# Altium schematic sheet Pwr_ctrl_cam.SchDoc
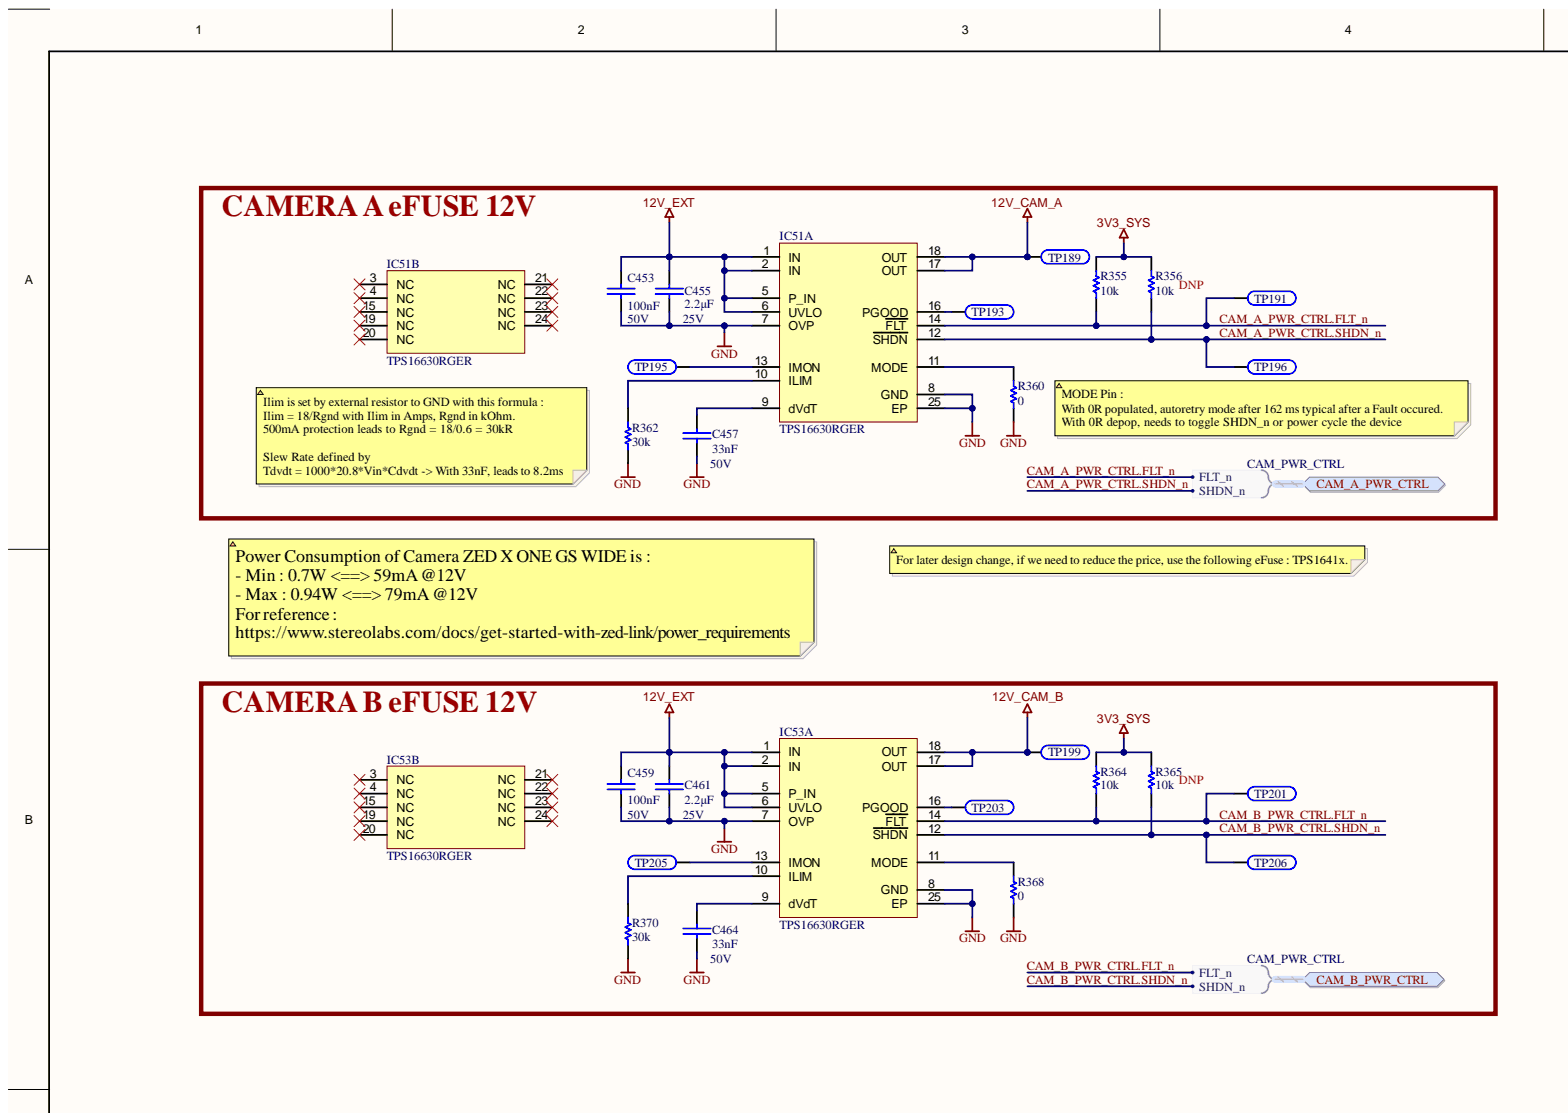
[diagram p0: Pwr_ctrl_cam.SchDoc, top left]
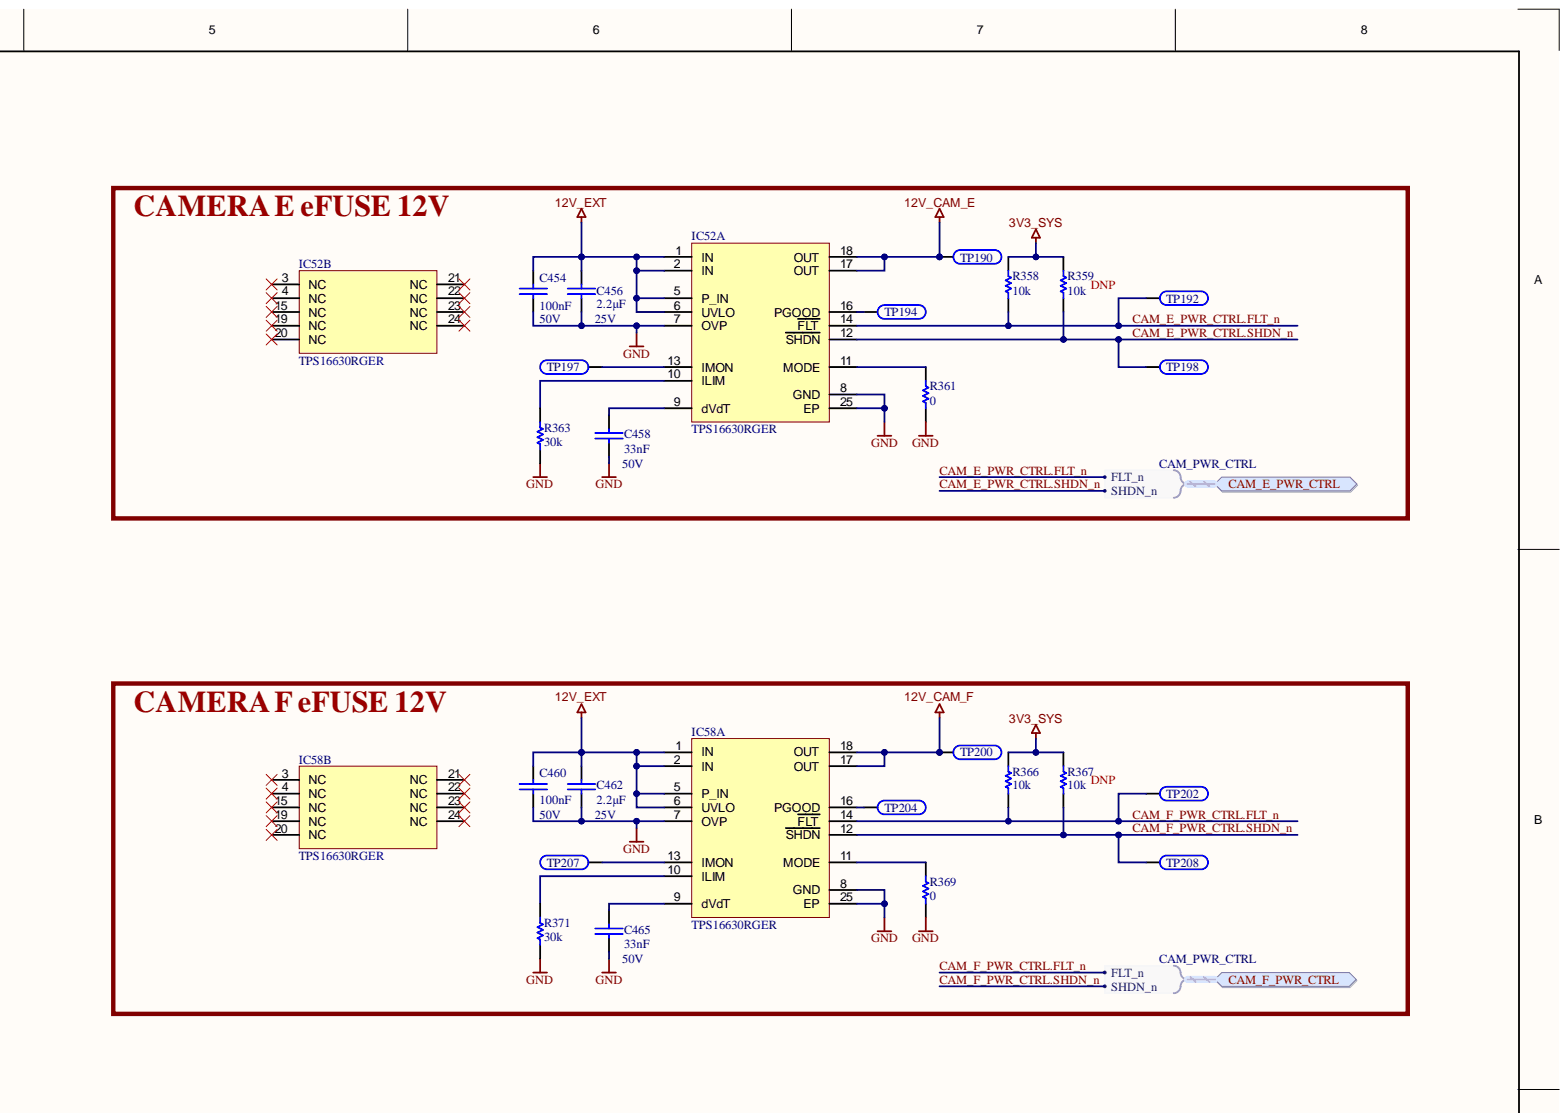
[diagram p1: Pwr_ctrl_cam.SchDoc, top right]
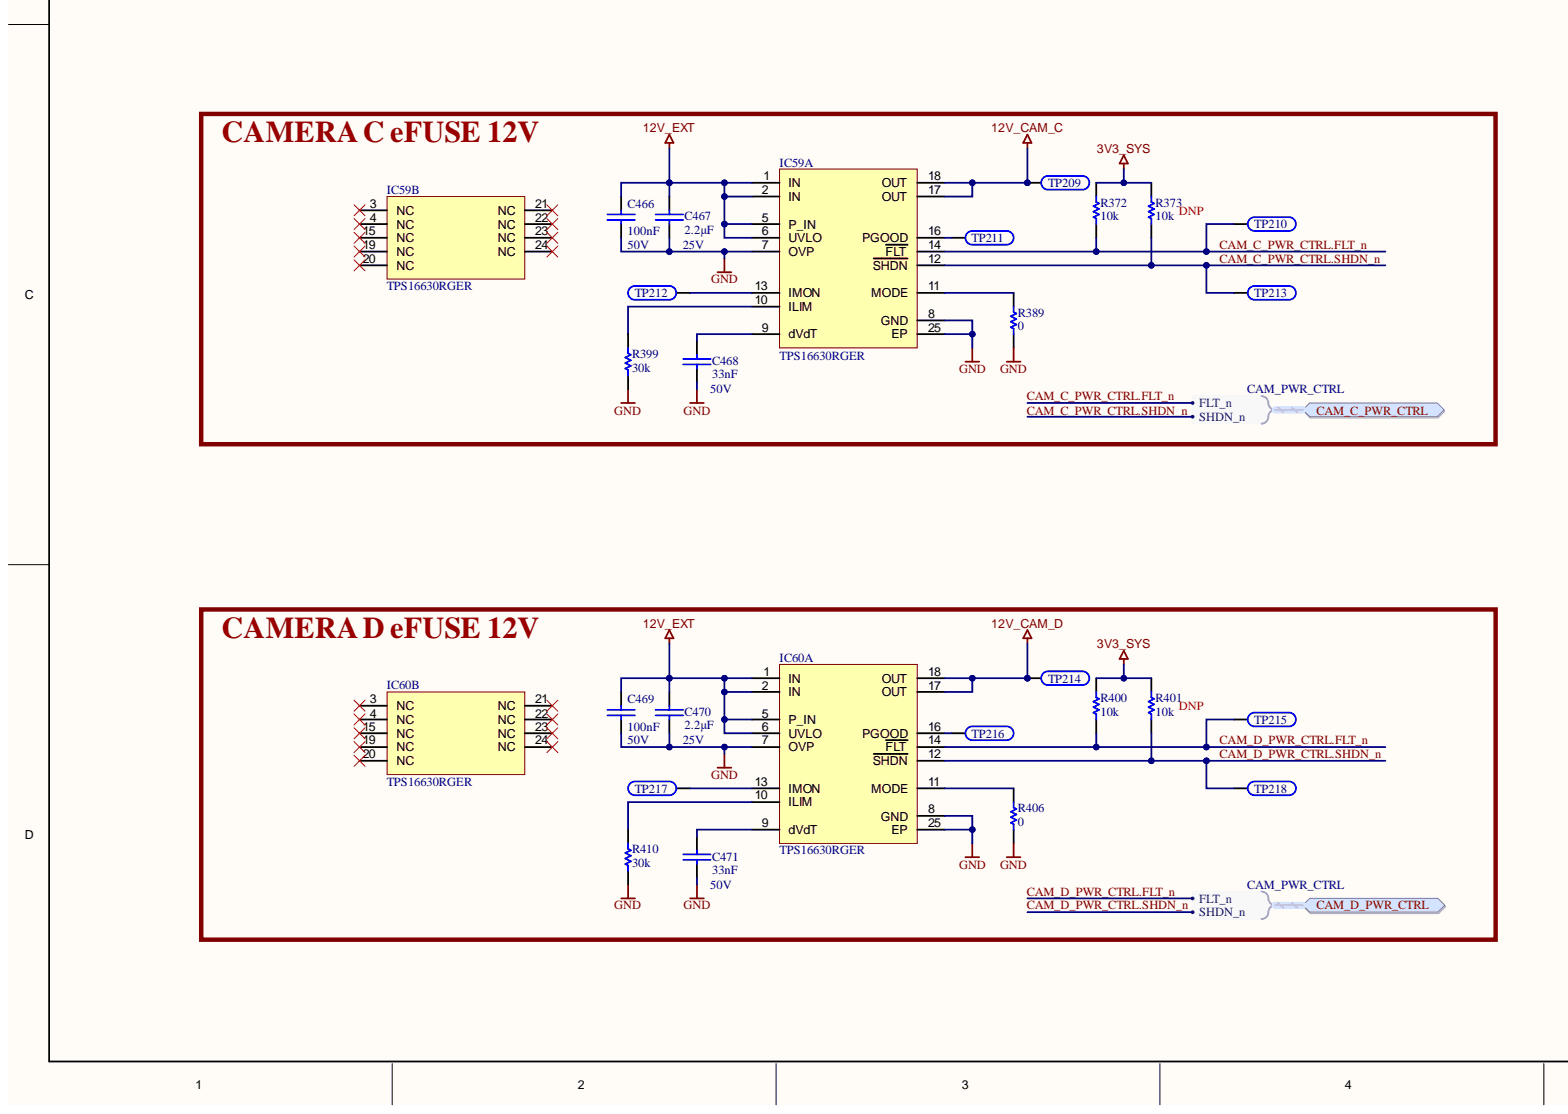
[diagram p2: Pwr_ctrl_cam.SchDoc, bottom left]
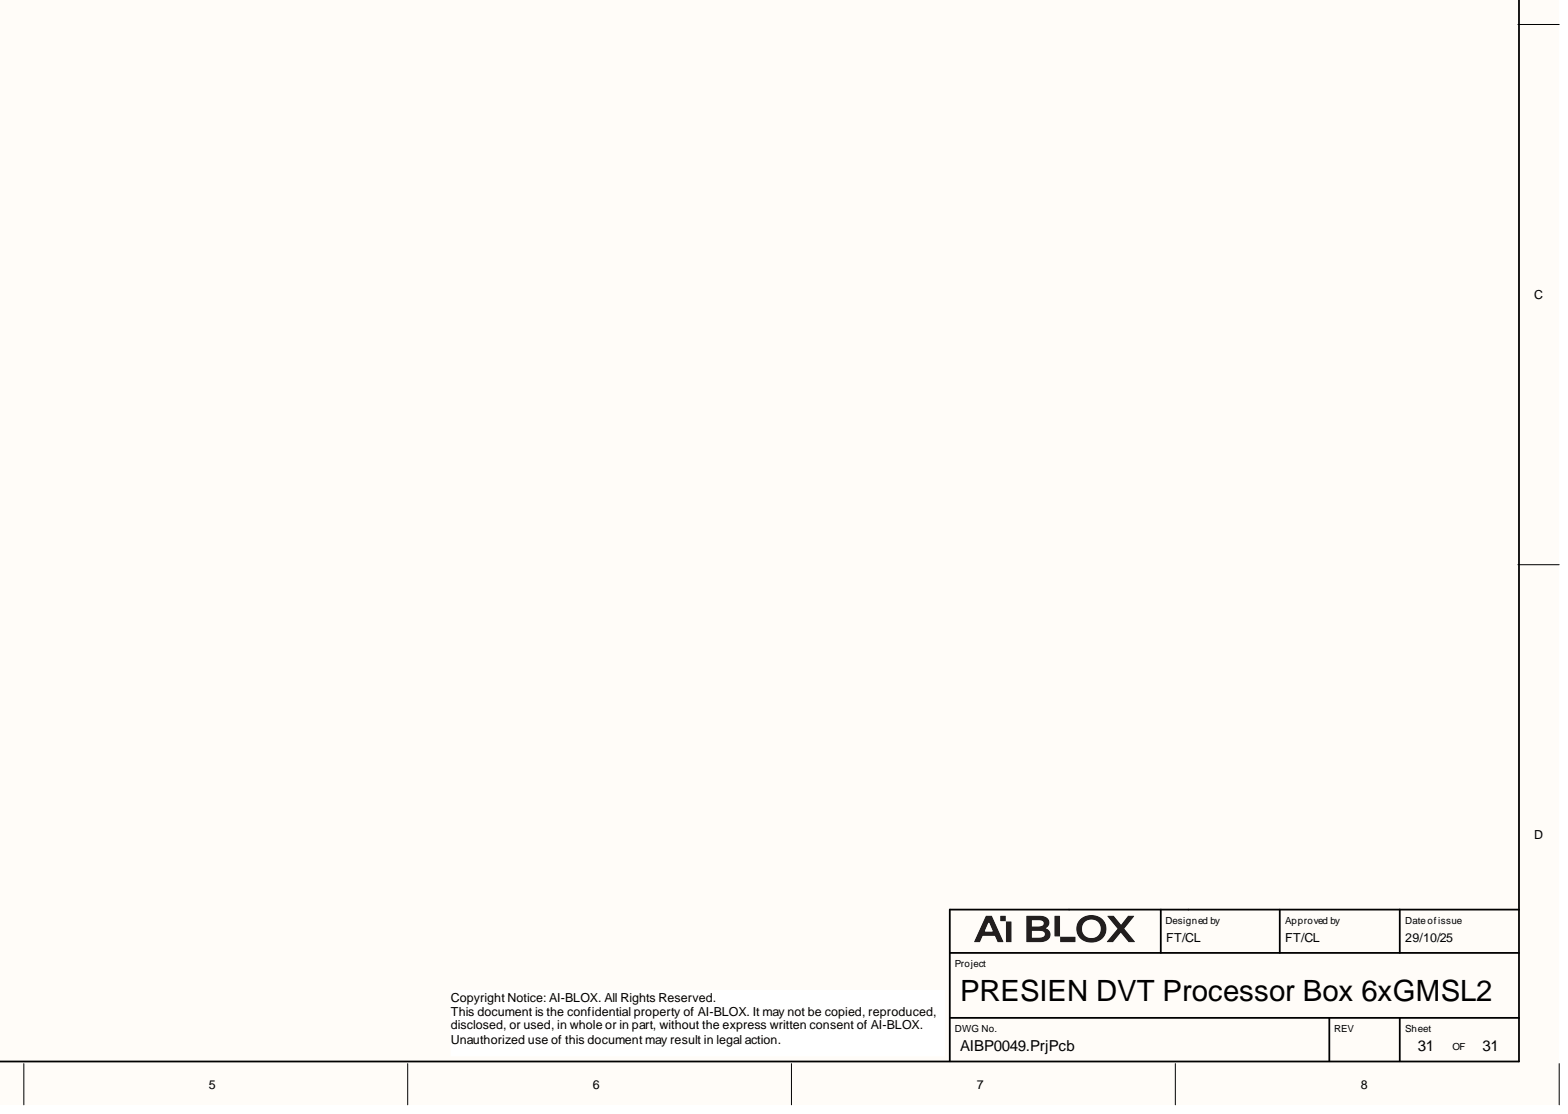
[diagram p3: Pwr_ctrl_cam.SchDoc, bottom right]

## Parts
C453  value 100nF  Voltage: 50V  [CAP0603-M]  (hidden comment: CC0603KPX7R9BB104)
C454  value 100nF  Voltage: 50V  [CAP0603-M]  (hidden comment: CC0603KPX7R9BB104)
C455  value 2.2µF  Voltage: 25V  [CAP0603-M]  (hidden comment: TMK107ABJ225KA-T)
C456  value 2.2µF  Voltage: 25V  [CAP0603-M]  (hidden comment: TMK107ABJ225KA-T)
C457  value 33nF  Voltage: 50V  [CAP0603-M]  (hidden comment: CC0603KRX7R9BB333)
C458  value 33nF  Voltage: 50V  [CAP0603-M]  (hidden comment: CC0603KRX7R9BB333)
C459  value 100nF  Voltage: 50V  [CAP0603-M]  (hidden comment: CC0603KPX7R9BB104)
C460  value 100nF  Voltage: 50V  [CAP0603-M]  (hidden comment: CC0603KPX7R9BB104)
C461  value 2.2µF  Voltage: 25V  [CAP0603-M]  (hidden comment: TMK107ABJ225KA-T)
C462  value 2.2µF  Voltage: 25V  [CAP0603-M]  (hidden comment: TMK107ABJ225KA-T)
C464  value 33nF  Voltage: 50V  [CAP0603-M]  (hidden comment: CC0603KRX7R9BB333)
C465  value 33nF  Voltage: 50V  [CAP0603-M]  (hidden comment: CC0603KRX7R9BB333)
C466  value 100nF  Voltage: 50V  [CAP0603-M]  (hidden comment: CC0603KPX7R9BB104)
C467  value 2.2µF  Voltage: 25V  [CAP0603-M]  (hidden comment: TMK107ABJ225KA-T)
C468  value 33nF  Voltage: 50V  [CAP0603-M]  (hidden comment: CC0603KRX7R9BB333)
C469  value 100nF  Voltage: 50V  [CAP0603-M]  (hidden comment: CC0603KPX7R9BB104)
C470  value 2.2µF  Voltage: 25V  [CAP0603-M]  (hidden comment: TMK107ABJ225KA-T)
C471  value 33nF  Voltage: 50V  [CAP0603-M]  (hidden comment: CC0603KRX7R9BB333)
IC51  TPS16630RGER  [QFN50P400X400X100_HS-25N]  (parts A,B)
IC52  TPS16630RGER  [QFN50P400X400X100_HS-25N]  (parts A,B)
IC53  TPS16630RGER  [QFN50P400X400X100_HS-25N]  (parts A,B)
IC58  TPS16630RGER  [QFN50P400X400X100_HS-25N]  (parts A,B)
IC59  TPS16630RGER  [QFN50P400X400X100_HS-25N]  (parts A,B)
IC60  TPS16630RGER  [QFN50P400X400X100_HS-25N]  (parts A,B)
R355  value 10k  [RES0603]  (hidden comment: RC0603FR-0710KL)
R356  value 10k  [RES0603]  (hidden comment: RC0603FR-0710KL)
R358  value 10k  [RES0603]  (hidden comment: RC0603FR-0710KL)
R359  value 10k  [RES0603]  (hidden comment: RC0603FR-0710KL)
R360  value 0  [RES0603]  (hidden comment: RC0603FR-070RL)
R361  value 0  [RES0603]  (hidden comment: RC0603FR-070RL)
R362  value 30k  [RES0603]  (hidden comment: AC0603FR-1330KL)
R363  value 30k  [RES0603]  (hidden comment: AC0603FR-1330KL)
R364  value 10k  [RES0603]  (hidden comment: RC0603FR-0710KL)
R365  value 10k  [RES0603]  (hidden comment: RC0603FR-0710KL)
R366  value 10k  [RES0603]  (hidden comment: RC0603FR-0710KL)
R367  value 10k  [RES0603]  (hidden comment: RC0603FR-0710KL)
R368  value 0  [RES0603]  (hidden comment: RC0603FR-070RL)
R369  value 0  [RES0603]  (hidden comment: RC0603FR-070RL)
R370  value 30k  [RES0603]  (hidden comment: AC0603FR-1330KL)
R371  value 30k  [RES0603]  (hidden comment: AC0603FR-1330KL)
R372  value 10k  [RES0603]  (hidden comment: RC0603FR-0710KL)
R373  value 10k  [RES0603]  (hidden comment: RC0603FR-0710KL)
R389  value 0  [RES0603]  (hidden comment: RC0603FR-070RL)
R399  value 30k  [RES0603]  (hidden comment: AC0603FR-1330KL)
R400  value 10k  [RES0603]  (hidden comment: RC0603FR-0710KL)
R401  value 10k  [RES0603]  (hidden comment: RC0603FR-0710KL)
R406  value 0  [RES0603]  (hidden comment: RC0603FR-070RL)
R410  value 30k  [RES0603]  (hidden comment: AC0603FR-1330KL)
TP189  Test_Point_1  [Test_Point_1]  (value not drawn)  (no BOM)
TP190  Test_Point_1  [Test_Point_1]  (value not drawn)  (no BOM)
TP191  Test_Point_1  [Test_Point_1]  (value not drawn)  (no BOM)
TP192  Test_Point_1  [Test_Point_1]  (value not drawn)  (no BOM)
TP193  Test_Point_1  [Test_Point_1]  (value not drawn)  (no BOM)
TP194  Test_Point_1  [Test_Point_1]  (value not drawn)  (no BOM)
TP195  Test_Point_1  [Test_Point_1]  (value not drawn)  (no BOM)
TP196  Test_Point_1  [Test_Point_1]  (value not drawn)  (no BOM)
TP197  Test_Point_1  [Test_Point_1]  (value not drawn)  (no BOM)
TP198  Test_Point_1  [Test_Point_1]  (value not drawn)  (no BOM)
TP199  Test_Point_1  [Test_Point_1]  (value not drawn)  (no BOM)
TP200  Test_Point_1  [Test_Point_1]  (value not drawn)  (no BOM)
TP201  Test_Point_1  [Test_Point_1]  (value not drawn)  (no BOM)
TP202  Test_Point_1  [Test_Point_1]  (value not drawn)  (no BOM)
TP203  Test_Point_1  [Test_Point_1]  (value not drawn)  (no BOM)
TP204  Test_Point_1  [Test_Point_1]  (value not drawn)  (no BOM)
TP205  Test_Point_1  [Test_Point_1]  (value not drawn)  (no BOM)
TP206  Test_Point_1  [Test_Point_1]  (value not drawn)  (no BOM)
TP207  Test_Point_1  [Test_Point_1]  (value not drawn)  (no BOM)
TP208  Test_Point_1  [Test_Point_1]  (value not drawn)  (no BOM)
TP209  Test_Point_1  [Test_Point_1]  (value not drawn)  (no BOM)
TP210  Test_Point_1  [Test_Point_1]  (value not drawn)  (no BOM)
TP211  Test_Point_1  [Test_Point_1]  (value not drawn)  (no BOM)
TP212  Test_Point_1  [Test_Point_1]  (value not drawn)  (no BOM)
TP213  Test_Point_1  [Test_Point_1]  (value not drawn)  (no BOM)
TP214  Test_Point_1  [Test_Point_1]  (value not drawn)  (no BOM)
TP215  Test_Point_1  [Test_Point_1]  (value not drawn)  (no BOM)
TP216  Test_Point_1  [Test_Point_1]  (value not drawn)  (no BOM)
TP217  Test_Point_1  [Test_Point_1]  (value not drawn)  (no BOM)
TP218  Test_Point_1  [Test_Point_1]  (value not drawn)  (no BOM)

## Ports
CAM_A_PWR_CTRL, CAM_A_PWR_CTRL.FLT_n, CAM_A_PWR_CTRL.SHDN_n, CAM_B_PWR_CTRL, CAM_B_PWR_CTRL.FLT_n, CAM_B_PWR_CTRL.SHDN_n, CAM_C_PWR_CTRL, CAM_C_PWR_CTRL.FLT_n, CAM_C_PWR_CTRL.SHDN_n, CAM_D_PWR_CTRL, CAM_D_PWR_CTRL.FLT_n, CAM_D_PWR_CTRL.SHDN_n, CAM_E_PWR_CTRL, CAM_E_PWR_CTRL.FLT_n, CAM_E_PWR_CTRL.SHDN_n, CAM_F_PWR_CTRL, CAM_F_PWR_CTRL.FLT_n, CAM_F_PWR_CTRL.SHDN_n

## Nets
3V3_SYS: R355.2 R356.2 R358.2 R359.2 R364.2 R365.2 R366.2 R367.2 R372.2 R373.2 R400.2 R401.2
12V_CAM_A: IC51.17(OUT) IC51.18(OUT) TP189.1
12V_CAM_B: IC53.17(OUT) IC53.18(OUT) TP199.1
12V_CAM_C: IC59.17(OUT) IC59.18(OUT) TP209.1
12V_CAM_D: IC60.17(OUT) IC60.18(OUT) TP214.1
12V_CAM_E: IC52.17(OUT) IC52.18(OUT) TP190.1
12V_CAM_F: IC58.17(OUT) IC58.18(OUT) TP200.1
12V_EXT: C453.1 C454.1 C455.1 C456.1 C459.1 C460.1 C461.1 C462.1 C466.1 C467.1 C469.1 C470.1 IC51.1(IN) IC51.2(IN) IC51.5(P_IN) IC51.6(UVLO) IC52.1(IN) IC52.2(IN) IC52.5(P_IN) IC52.6(UVLO) IC53.1(IN) IC53.2(IN) IC53.5(P_IN) IC53.6(UVLO) IC58.1(IN) IC58.2(IN) IC58.5(P_IN) IC58.6(UVLO) IC59.1(IN) IC59.2(IN) IC59.5(P_IN) IC59.6(UVLO) IC60.1(IN) IC60.2(IN) IC60.5(P_IN) IC60.6(UVLO)
GND: C453.2 C454.2 C455.2 C456.2 C457.2 C458.2 C459.2 C460.2 C461.2 C462.2 C464.2 C465.2 C466.2 C467.2 C468.2 C469.2 C470.2 C471.2 IC51.7(OVP) IC51.8(GND) IC51.25(EP) IC52.7(OVP) IC52.8(GND) IC52.25(EP) IC53.7(OVP) IC53.8(GND) IC53.25(EP) IC58.7(OVP) IC58.8(GND) IC58.25(EP) IC59.7(OVP) IC59.8(GND) IC59.25(EP) IC60.7(OVP) IC60.8(GND) IC60.25(EP) R360.1 R361.1 R362.1 R363.1 R368.1 R369.1 R370.1 R371.1 R389.1 R399.1 R406.1 R410.1
CAM_A_PWR_CTRL.FLT_n: IC51.14(FLT) R355.1 TP191.1 ; port CAM_A_PWR_CTRL
CAM_A_PWR_CTRL.SHDN_n: IC51.12(SHDN) R356.1 TP196.1 ; port CAM_A_PWR_CTRL
CAM_B_PWR_CTRL.FLT_n: IC53.14(FLT) R364.1 TP201.1 ; port CAM_B_PWR_CTRL
CAM_B_PWR_CTRL.SHDN_n: IC53.12(SHDN) R365.1 TP206.1 ; port CAM_B_PWR_CTRL
CAM_C_PWR_CTRL.FLT_n: IC59.14(FLT) R372.1 TP210.1 ; port CAM_C_PWR_CTRL
CAM_C_PWR_CTRL.SHDN_n: IC59.12(SHDN) R373.1 TP213.1 ; port CAM_C_PWR_CTRL
CAM_D_PWR_CTRL.FLT_n: IC60.14(FLT) R400.1 TP215.1 ; port CAM_D_PWR_CTRL
CAM_D_PWR_CTRL.SHDN_n: IC60.12(SHDN) R401.1 TP218.1 ; port CAM_D_PWR_CTRL
CAM_E_PWR_CTRL.FLT_n: IC52.14(FLT) R358.1 TP192.1 ; port CAM_E_PWR_CTRL
CAM_E_PWR_CTRL.SHDN_n: IC52.12(SHDN) R359.1 TP198.1 ; port CAM_E_PWR_CTRL
CAM_F_PWR_CTRL.FLT_n: IC58.14(FLT) R366.1 TP202.1 ; port CAM_F_PWR_CTRL
CAM_F_PWR_CTRL.SHDN_n: IC58.12(SHDN) R367.1 TP208.1 ; port CAM_F_PWR_CTRL
NetC457_1: C457.1 IC51.9(dVdT)
NetC458_1: C458.1 IC52.9(dVdT)
NetC464_1: C464.1 IC53.9(dVdT)
NetC465_1: C465.1 IC58.9(dVdT)
NetC468_1: C468.1 IC59.9(dVdT)
NetC471_1: C471.1 IC60.9(dVdT)
NetIC51_10: IC51.10(ILIM) R362.2
NetIC51_11: IC51.11(MODE) R360.2
NetIC51_13: IC51.13(IMON) TP195.1
NetIC51_16: IC51.16(PGOOD) TP193.1
NetIC52_10: IC52.10(ILIM) R363.2
NetIC52_11: IC52.11(MODE) R361.2
NetIC52_13: IC52.13(IMON) TP197.1
NetIC52_16: IC52.16(PGOOD) TP194.1
NetIC53_10: IC53.10(ILIM) R370.2
NetIC53_11: IC53.11(MODE) R368.2
NetIC53_13: IC53.13(IMON) TP205.1
NetIC53_16: IC53.16(PGOOD) TP203.1
NetIC58_10: IC58.10(ILIM) R371.2
NetIC58_11: IC58.11(MODE) R369.2
NetIC58_13: IC58.13(IMON) TP207.1
NetIC58_16: IC58.16(PGOOD) TP204.1
NetIC59_10: IC59.10(ILIM) R399.2
NetIC59_11: IC59.11(MODE) R389.2
NetIC59_13: IC59.13(IMON) TP212.1
NetIC59_16: IC59.16(PGOOD) TP211.1
NetIC60_10: IC60.10(ILIM) R410.2
NetIC60_11: IC60.11(MODE) R406.2
NetIC60_13: IC60.13(IMON) TP217.1
NetIC60_16: IC60.16(PGOOD) TP216.1

Not connected: IC51 3(NC) 4(NC) 15(NC) 19(NC) 20(NC) 21(NC) 22(NC) 23(NC) 24(NC); IC52 3(NC) 4(NC) 15(NC) 19(NC) 20(NC) 21(NC) 22(NC) 23(NC) 24(NC); IC53 3(NC) 4(NC) 15(NC) 19(NC) 20(NC) 21(NC) 22(NC) 23(NC) 24(NC); IC58 3(NC) 4(NC) 15(NC) 19(NC) 20(NC) 21(NC) 22(NC) 23(NC) 24(NC); IC59 3(NC) 4(NC) 15(NC) 19(NC) 20(NC) 21(NC) 22(NC) 23(NC) 24(NC); IC60 3(NC) 4(NC) 15(NC) 19(NC) 20(NC) 21(NC) 22(NC) 23(NC) 24(NC)

## Library data of the parts
C453 C454 C459 C460 C466 C469 = CMP-81.02.0001-14: CAP CER 0.1UF 50V X7R 0603; Manufacturer 1: YAGEO; GRADE: NO; Case/Package: 0603; Component Kind: Standard; DatasheetDocument: https://datasheet.octopart.com/CC0603KPX7R9BB104-Yageo-datasheet-151948686.pdf; Internal Ref: 81.02.0001; Manufacturer Part Number 1: CC0603KPX7R9BB104; ROHS: YES; Library Name: AI Blox; Publisher: Hans Stevens
C455 C456 C461 C462 C467 C470 = CMP-81.02.0094-1: CAP CER 2.2UF 25V X5R 0603; Manufacturer 1: Taiyo Yuden; GRADE: NO; Tolerance: 10%; Case/Package: 0603; Component Kind: Standard; DatasheetDocument: https://mm.digikey.com/Volume0/opasdata/d220001/medias/docus/4819/TMK107ABJ225KA-T_SS.pdf; Internal Ref: 81.02.0094; Manufacturer Part Number 1: TMK107ABJ225KA-T; ROHS: Yes; Library Name: AI Blox
C457 C458 C464 C465 C468 C471 = CMP-81.02.0053-9: CAP CER 0.033UF 50V X7R 0603; Manufacturer 1: YAGEO; GRADE: NO; Tolerance: 10%; Case/Package: 0603; Component Kind: Standard; DatasheetDocument: https://octopart.com/datasheet/cc0603krx7r9bb333-yageo-11543599; Internal Ref: 81.02.0053; Manufacturer Part Number 1: CC0603KRX7R9BB333; ROHS: YES; Library Name: AI Blox; Publisher: Marc Goovaerts
IC51-IC53 IC58-IC60 = CMP-81.04.0128-6: IC ELECTRONIC FUSE 2% 24VQFN; Manufacturer 1: TI; GRADE: NO; Component Kind: Standard; DatasheetDocument: https://www.ti.com/product/TPS1663#tech-docs?HQS=ti-null-null-verifimanuf_df-manu-pf-octopart-wwe; Internal Ref: 81.04.0128; Manufacturer Part Number 1: TPS16630RGER; Package: 24VQFN; ROHS: YES; Library Name: AI Blox; Publisher: Hans Stevens
R355 R356 R358 R359 R364-R367 R372 R373 R400 R401 = CMP-81.01.0021-5: RES 10K OHM 1% 1/10W 0603; GRADE: NO; Case/Package: 0603; Component Kind: Standard; DatasheetDocument: https://octopart.com/datasheet/rc0603fr-0710kl-yageo-40025538; Internal Ref: 81.01.0021; ROHS: YES; Library Name: AI Blox; Publisher: Hans Stevens
R360 R361 R368 R369 R389 R406 = CMP-81.01.0005-5: RES 0 OHM JUMPER 1/10W 0603; GRADE: NO; Case/Package: 0603; Component Kind: Standard; DatasheetDocument: https://octopart.com/datasheet/rc0603fr-070rl-yageo-1180803; Internal Ref: 81.01.0005; ROHS: YES; Library Name: AI Blox; Publisher: Hans Stevens
R362 R363 R370 R371 R399 R410 = CMP-81.01.0193-1: RES 30K OHM 1% 1/10W 0603; GRADE: NO; Case/Package: 0603; Component Kind: Standard; DatasheetDocument: https://www.yageo.com/upload/media/product/productsearch/datasheet/rchip/PYu-AC_51_RoHS_L_11.pdf; Internal Ref: 81.01.0193; ROHS: Yes; Library Name: AI Blox; Publisher: Clement Letouze
TP189-TP218 = CMP-81.00.0006-9: 0.8mm testpoint; Library Name: AI Blox
